# Altium schematic sheet BMS Carrier - Battery Relay Controls.SchDoc("BMS Carrier - Battery Relay Controls")
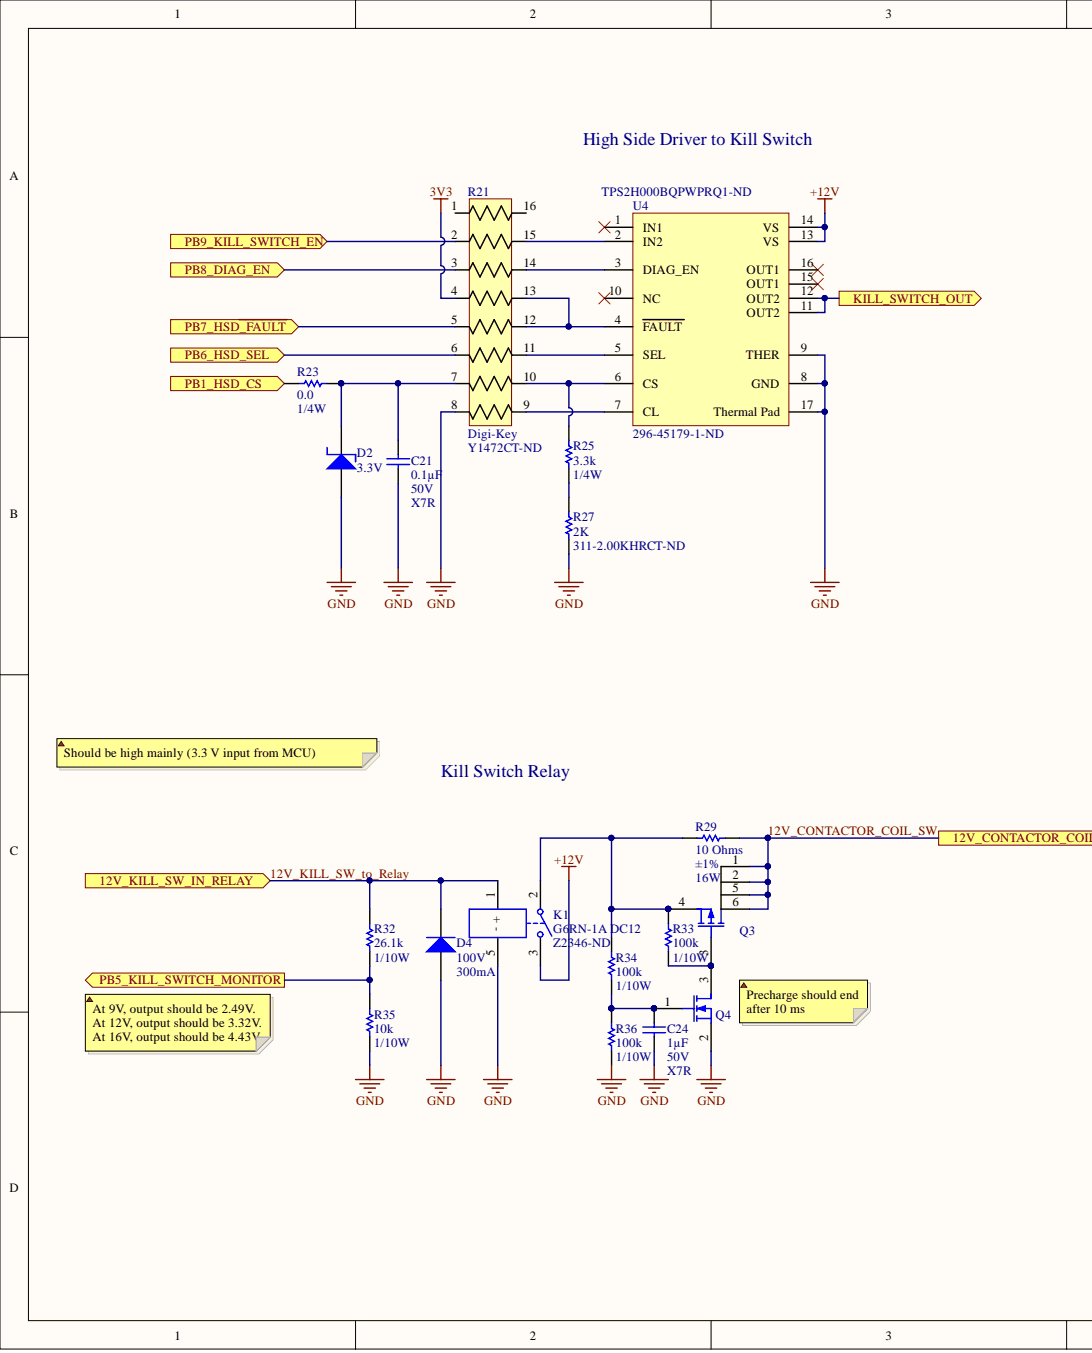
[diagram p0: BMS Carrier - Battery Relay Controls.SchDoc, left]
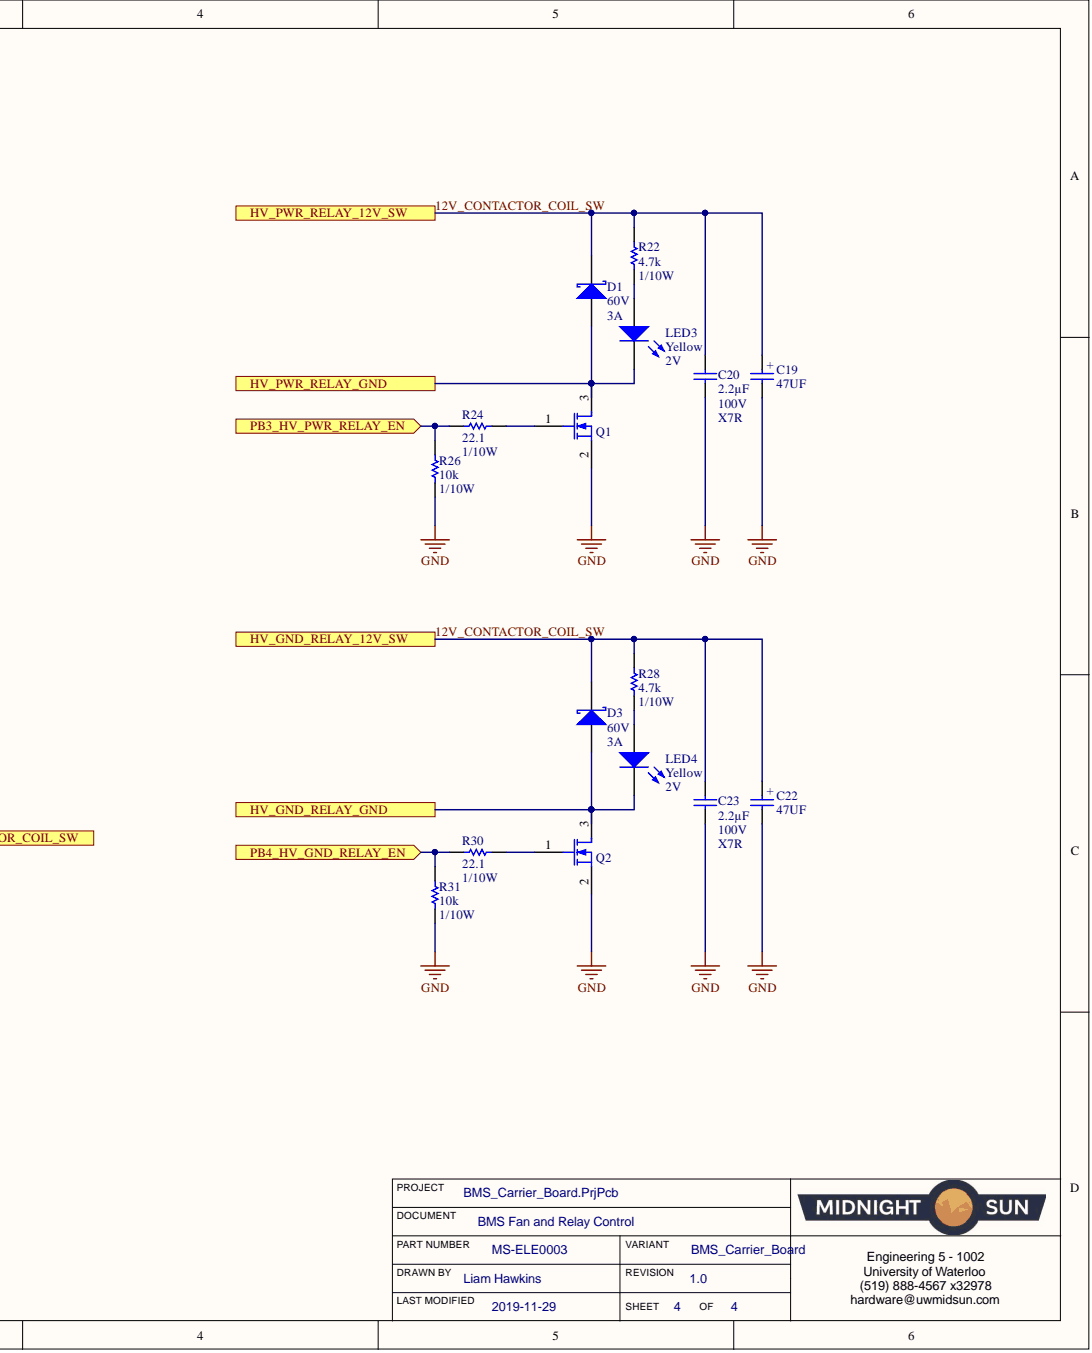
[diagram p1: BMS Carrier - Battery Relay Controls.SchDoc, right]

## Parts
C19  47UF  [CAP ALUM 6.3MM RADIAL SMD]
C20  Voltage: 100V  Temperature Coefficient: X7R  Capacitance: 2.2µF  [CAP, 1206]  (hidden comment: CAP CER 2.2UF 100V ±20% X7R 1206)
C21  Voltage: 50V  Temperature Coefficient: X7R  Capacitance: 0.1µF  [CAP, 0603]  (hidden comment: CAP CER 0.1UF 50V 10% X7R 0603)
C22  47UF  [CAP ALUM 6.3MM RADIAL SMD]
C23  Voltage: 100V  Temperature Coefficient: X7R  Capacitance: 2.2µF  [CAP, 1206]  (hidden comment: CAP CER 2.2UF 100V ±20% X7R 1206)
C24  Voltage: 50V  Temperature Coefficient: X7R  Capacitance: 1µF  [CAP, 0603]  (hidden comment: CAP CER 1UF 50V 10% X7R 0603)
D1  Voltage - DC Reverse (Vr) (Max): 60V  Current - Average Rectified (Io): 3A  [Diode, SMA]  (hidden comment: DIODE SCHOTTKY 60V 3A SMA)
D2  Zener Voltage: 3.3V  [sot23]  (hidden comment: DIODE ZENER 3.3V 250mW)
D3  Voltage - DC Reverse (Vr) (Max): 60V  Current - Average Rectified (Io): 3A  [Diode, SMA]  (hidden comment: DIODE SCHOTTKY 60V 3A SMA)
D4  Max Repetitive Reverse Voltage (Vrrm): 100V  Forward Current: 300mA  [DIODE GEN PURP 100V 300MA SOD123]  (hidden comment: DIODE GEN PURP 100V 300MA SOD123)
K1  G6RN-1A DC12  Supplier Part Number 2: Z2346-ND  [RELAY SPST 8A 12VDC]
LED3  Voltage - Forward (Vf) (Typ): 2V  Color: Yellow  [LED_0603]  (hidden comment: LED YELLOW CLEAR 2.1V 0603)
LED4  Voltage - Forward (Vf) (Typ): 2V  Color: Yellow  [LED_0603]  (hidden comment: LED YELLOW CLEAR 2.1V 0603)
Q1  MOSFET N-CH 30V 6.2A 0.9W SOT-23  [MOSFET N-CH 30V 6.2A 0.9W SOT-23]  (value not drawn)
Q2  MOSFET N-CH 30V 6.2A 0.9W SOT-23  [MOSFET N-CH 30V 6.2A 0.9W SOT-23]  (value not drawn)
Q3  MOSFET P-CH 30V 4A 1.6W SOT-23-6  [P-MOSFET]  (value not drawn)
Q4  MOSFET N-CH 30V 6.2A 0.9W SOT-23  [MOSFET N-CH 30V 6.2A 0.9W SOT-23]  (value not drawn)
R21  Supplier Part Number 1: Y1472CT-ND  Supplier 1: Digi-Key  [RES ARRAY, 8 POS 1506]  (hidden comment: RES ARRAY 4K7 OHM 5% 8RES)
R22  Resistance (Ohms): 4.7k  Power (Watts): 1/10W  [RES, 0603]  (hidden comment: RES 4.7K OHM 1% 1/10W 0603)
R23  Resistance: 0.0  [RES, 0603]  (hidden comment: RES 0.0 OHM 1/4W 0603)
R24  Resistance: 22.1  [RES, 0603]  (hidden comment: RES 22.1 OHM 1% 1/10W 0603)
R25  Resistance: 3.3k  Power: 1/4W  [RES, 0603]  (hidden comment: RES 3.3K OHM 1% 1/4W 0603)
R26  Resistance: 10k  Power: 1/10W  [RES, 0603]  (hidden comment: RES 10K OHM 1% 1/10W 0603)
R27  2K  Supplier Part Number 1: 311-2.00KHRCT-ND  [RES, 0603]
R28  Resistance (Ohms): 4.7k  Power (Watts): 1/10W  [RES, 0603]  (hidden comment: RES 4.7K OHM 1% 1/10W 0603)
R29  Resistance: 10 Ohms  [RES2512]  (hidden comment: RES 10 OHM 1% 16W 2512)
R30  Resistance: 22.1  [RES, 0603]  (hidden comment: RES 22.1 OHM 1% 1/10W 0603)
R31  Resistance: 10k  Power: 1/10W  [RES, 0603]  (hidden comment: RES 10K OHM 1% 1/10W 0603)
R32  Resistance: 26.1k  Power: 1/10W  [RES, 0603]  (hidden comment: RES 26.1K OHM 1% 1/10W 0603)
R33  Resistance: 100k  Power: 1/10W  [RES, 0603]  (hidden comment: RES 100K OHM 5% 1/8W 0603)
R34  Resistance: 100k  Power: 1/10W  [RES, 0603]  (hidden comment: RES 100K OHM 5% 1/8W 0603)
R35  Resistance: 10k  Power: 1/10W  [RES, 0603]  (hidden comment: RES 10K OHM 1% 1/10W 0603)
R36  Resistance: 100k  Power: 1/10W  [RES, 0603]  (hidden comment: RES 100K OHM 5% 1/8W 0603)
U4  296-45179-1-ND  Supplier Part Number 1: TPS2H000BQPWPRQ1-ND  [IC HSD Dual-Channel TSOP65P640X120_HS-17N]

## Ports
12V_CONTACTOR_COIL_SW, 12V_KILL_SW_IN_RELAY, HV_GND_RELAY_12V_SW, HV_GND_RELAY_GND, HV_PWR_RELAY_12V_SW, HV_PWR_RELAY_GND, KILL_SWITCH_OUT, PB1_HSD_CS, PB3_HV_PWR_RELAY_EN, PB4_HV_GND_RELAY_EN, PB5_KILL_SWITCH_MONITOR, PB6_HSD_SEL, PB7_HSD~{_FAULT}, PB8_DIAG_EN, PB9_KILL_SWITCH_EN

## Nets
3V3: R21.4
GND: C19.2 C20.2 C21.2 C22.2 C23.2 C24.2 D2.3 D4.1 K1.5(-) Q1.2 Q2.2 Q4.2 R21.8 R26.2 R27.2 R31.2 R35.2 R36.2 U4.8(GND) U4.9(THER) U4.17(Thermal_Pad)
+12V: C19.1 C20.1 C22.1 C23.1 D1.2 D3.2 D4.2 K1.1(+) K1.3 Q3.1(±1%) Q3.2(16W) Q3.5 Q3.6 R22.1 R28.1 R29.2 R32.1 U4.13(VS) U4.14(VS) ; port 12V_CONTACTOR_COIL_SW, port 12V_KILL_SW_IN_RELAY, port HV_GND_RELAY_12V_SW, port HV_PWR_RELAY_12V_SW  [also labelled 12V_CONTACTOR_COIL_SW, 12V_KILL_SW_to_Relay]
HV_GND_RELAY_GND: D3.1 LED4.2 Q2.3 ; port HV_GND_RELAY_GND
HV_PWR_RELAY_GND: D1.1 LED3.2 Q1.3 ; port HV_PWR_RELAY_GND
KILL_SWITCH_OUT: U4.11(OUT2) U4.12(OUT2) ; port KILL_SWITCH_OUT
PB1_HSD_CS: R23.1 ; port PB1_HSD_CS
PB3_HV_PWR_RELAY_EN: R24.1 R26.1 ; port PB3_HV_PWR_RELAY_EN
PB4_HV_GND_RELAY_EN: R30.1 R31.1 ; port PB4_HV_GND_RELAY_EN
PB5_KILL_SWITCH_MONITOR: R32.2 R35.1 ; port PB5_KILL_SWITCH_MONITOR
PB6_HSD_SEL: R21.6 ; port PB6_HSD_SEL
PB7_HSD~{_FAULT}: R21.5 ; port PB7_HSD~{_FAULT}
PB8_DIAG_EN: R21.3 ; port PB8_DIAG_EN
PB9_KILL_SWITCH_EN: R21.2 ; port PB9_KILL_SWITCH_EN
NetC21_1: C21.1 D2.1 R21.7 R23.2
NetC24_1: C24.1 Q4.1 R34.2 R36.1
NetK1_2: K1.2 Q3.4 R29.1 R33.2 R34.1
NetLED3_1: LED3.1 R22.2
NetLED4_1: LED4.1 R28.2
NetQ1_1: Q1.1 R24.2
NetQ2_1: Q2.1 R30.2
NetQ3_3: Q3.3 Q4.3 R33.1
NetR21_9: R21.9 U4.7(CL)
NetR21_10: R21.10 R25.1 U4.6(CS)
NetR21_11: R21.11 U4.5(SEL)
NetR21_12: R21.12 R21.13 U4.4(FAULT)
NetR21_14: R21.14 U4.3(DIAG_EN)
NetR21_15: R21.15 U4.2(IN2)
NetR25_2: R25.2 R27.1

Not connected: R21 1 16; U4 1(IN1) 10(NC) 15(OUT1) 16(OUT1)

## Library data of the parts
C19 C22 = CAP ALUM 47UF 20% 35V SMD: 47µF 35V Aluminum Electrolytic Capacitors Radial, Can - SMD 1000 Hrs @ 85°C; Manufacturer Part Number: EEE-1VA470WP; Manufacturer: Panasonic; Tolerance: 20%; Ripple Current (AC): 70mA; Life (Hours): 1000Hour; Voltage Rating (DC): 35V; Voltage Rating: 35V; Termination: SMD/SMT; Supplier 1: Digi-Key; Stock 1: 2820; Ripple Current: 70mA; REACH SVHC: Unknown; Radiation Hardening: No; Pricing 1: 1=0.38, 10=0.263, 100=0.1579, 500=0.11846 (USD); Packaging: Tape and Reel; Package Quantity: 1; Mount: Surface Mount,PCB; Min Operating Temperature: -40°C; Max Operating Temperature: 85°C; Length: 5.5mm; Lead Free: Lead Free; Height - Seated (Max): 0.213inch; Height: 5.4mm; Diameter: 6.3mm; Contact Plating: Tin; ComponentLink6URL: https://datasheet.ciiva.com/30206/11aba0000ce2-30206253.pdf; ComponentLink6Description: https://datasheet.ciiva.com/30206/11aba0000ce2-30206253.pdf; ComponentLink5URL: https://datasheet.ciiva.com/16507/aba0000ce2-16507965.pdf; ComponentLink5Description: https://datasheet.ciiva.com/16507/aba0000ce2-16507965.pdf; ComponentLink4URL: https://datasheet.ciiva.com/15165/getdatasheetpartid-86239-15165868.pdf; ComponentLink4Description: https://datasheet.ciiva.com/15165/getdatasheetpartid-86239-15165868.pdf; ComponentLink3URL: https://datasheet.ciiva.com/14598/70255710-14598937.pdf; ComponentLink3Description: https://datasheet.ciiva.com/14598/70255710-14598937.pdf; ComponentLink2URL: https://datasheet.ciiva.com/2983/aba0000ce2-2983927.pdf; ComponentLink2Description: https://datasheet.ciiva.com/2983/aba0000ce2-2983927.pdf; ComponentLink1URL: https://datasheet.ciiva.com/2983/aba0000ce2-2983926.pdf; ComponentLink1Description: https://datasheet.ciiva.com/2983/aba0000ce2-2983926.pdf; Component Kind: Standard; Case/Package: Radial; Capacitance: 47uF; Library Name: Schematic Diagrams.SchLib
C20 C23 = CAP CER 2.2UF 100V ±20% X7R 1206: 2.2µF ±20% 100V Ceramic Capacitor X7R 1206 (3216 Metric); Manufacturer Part Number 1: GRM31CR72A225MA73L; Manufacturer 1: Murata Electronics North America; Tolerance: ±20%; Thickness (Max): 0.071" (1.80mm); Supplier Part Number 1: 490-12773-1-ND; Supplier 1: Digi-Key; Size / Dimension: 0.126" L x 0.063" W (3.20mm x 1.60mm); Ratings: -; Part Status: Active; Packaging: Cut Tape (CT); Package / Case: 1206 (3216 Metric); Operating Temperature: -55°C ~ 125°C; Mounting Type: Surface Mount, MLCC; Lead Style: -; Lead Spacing: -; Height - Seated (Max): -; ComponentLink1URL: http://www.murata.com/~/media/webrenewal/support/library/catalog/products/capacitor/mlcc/c02e.ashx; ComponentLink1Description: http://www.murata.com/~/media/webrenewal/support/library/catalog/products/capacitor/mlcc/c02e.ashx; Component Kind: Standard; Library Name: Schematic Diagrams.SchLib
C21 = CAP CER 0.1UF 50V 10% X7R 0603: 0.10µF ±10% 50V Ceramic Capacitor X7R 0603 (1608 Metric); Manufacturer Part Number 1: 06035C104KAT2A; Manufacturer 1: AVX Corporation; Tolerance: ±10%; Thickness (Max): 0.035" (0.90mm); Supplier Part Number 1: 478-5052-1-ND; Supplier 1: Digi-Key; Size / Dimension: 0.063" L x 0.032" W (1.60mm x 0.81mm); Ratings: -; Part Status: Active; Packaging: Cut Tape (CT); Package / Case: 0603 (1608 Metric); Operating Temperature: -55°C ~ 125°C; Mounting Type: Surface Mount, MLCC; Lead Style: -; Lead Spacing: -; Height - Seated (Max): -; ComponentLink1URL: http://datasheets.avx.com/X7RDielectric.pdf; ComponentLink1Description: http://datasheets.avx.com/X7RDielectric.pdf; Component Kind: Standard; Library Name: Schematic Diagrams.SchLib
C24 = CAP CER 1UF 50V 10% X7R 0603: 1µF ±10% 50V Ceramic Capacitor X7R 0603 (1608 Metric); Manufacturer Part Number 1: UMK107AB7105KA-T; Manufacturer 1: Taiyo Yuden; Tolerance: ±10%; Thickness (Max): 0.037" (0.95mm); Supplier Part Number 1: 587-3247-1-ND; Supplier 1: Digi-Key; Size / Dimension: 0.063" L x 0.031" W (1.60mm x 0.80mm); Ratings: -; Part Status: Active; Packaging: Cut Tape (CT); Package / Case: 0603 (1608 Metric); Operating Temperature: -55°C ~ 125°C; Mounting Type: Surface Mount, MLCC; Lead Style: -; Lead Spacing: -; Height - Seated (Max): -; ComponentLink1URL: http://www.yuden.co.jp/productdata/catalog/en/mlcc_all_e.pdf; ComponentLink1Description: http://www.yuden.co.jp/productdata/catalog/en/mlcc_all_e.pdf; Component Kind: Standard; Library Name: Schematic Diagrams.SchLib
D1 D3 = DIODE SCHOTTKY 60V 3A SMA: Diode Schottky 60V 3A Surface Mount SMA; Manufacturer Part Number 1: B360A-13-F; Manufacturer 1: Diodes Incorporated; Voltage - Forward (Vf) (Max) @ If: 700mV @ 3A; Speed: Fast Recovery =< 500ns, > 200mA (Io); Current - Reverse Leakage @ Vr: 500µA @ 60V; Capacitance @ Vr, F: 200pF @ 4V, 1MHz; Supplier Part Number 1: B360A-FDICT-ND; Supplier Device Package: SMA; Supplier 1: Digi-Key; Part Status: Active; Packaging: Cut Tape (CT); Package / Case: DO-214AC, SMA; Operating Temperature - Junction: -55°C ~ 150°C; Mounting Type: Surface Mount; Diode Type: Schottky; ComponentLink1URL: https://www.diodes.com/assets/Datasheets/ds30891.pdf; ComponentLink1Description: https://www.diodes.com/assets/Datasheets/ds30891.pdf; Component Kind: Standard; Library Name: Schematic Diagrams.SchLib
D2 = DIODE ZENER 3.3V 250mW: Zener Diode 3.3V 250mW ±6.06% Surface Mount SSD3; Manufacturer Part Number: BZX84C3V3LFHT116; Manufacturer: Rohm; Impedance: 95R; Tolerance: 6.06%; Supplier Part Number 1: BZX84C3V3LFHT116CT-ND; Supplier 1: Digi-Key; Stock 1: 2960; RoHS: No; Pricing 1: 1=0.13000, 10=0.12200, 25=0.11600, 100=0.06630, 250=0.04912, 500=0.04078, 1000=0.02781 (USD); Packaging: Cut Tape; Mount: Surface Mount; Max Power Dissipation: 250mW; ComponentLink3URL: https://www.rohm.com/datasheet/BZX84C3V3LFH/bzx84c3v3lfht116-e?src-supplier=Digi-Key; ComponentLink3Description: https://www.rohm.com/datasheet/BZX84C3V3LFH/bzx84c3v3lfht116-e?src-supplier=Digi-Key; ComponentLink2URL: http://rohmfs.rohm.com/en/techdata_basic/diode/taping/Taping_SSD3M_T116-e.pdf?src-supplier=Digi-Key; ComponentLink2Description: http://rohmfs.rohm.com/en/techdata_basic/diode/taping/Taping_SSD3M_T116-e.pdf?src-supplier=Digi-Key; ComponentLink1URL: http://www.farnell.com/datasheets/2328411.pdf?src-supplier=Farnell; ComponentLink1Description: http://www.farnell.com/datasheets/2328411.pdf?src-supplier=Farnell; Component Kind: Standard; Case/Package: TO-236-3; Library Name: Schematic Diagrams.SchLib
D4 = DIODE GEN PURP 100V 300MA SOD123: Diode Standard 100V 300mA (DC) Surface Mount SOD-123; Manufacturer Part Number: 1N4148WQ-7-F; Manufacturer: Diodes Zetex; Reverse Recovery Time: 4ns; Peak Reverse Current: 50uA; Peak Non-Repetitive Surge Current: 2A; Max Power Dissipation: 400mW; Forward Voltage: 1.25V; Element Configuration: Single; Weight: 0.000353oz; Supplier Part Number 1: 1N4148WQ-7-FDICT-ND; Supplier 1: Digi-Key; Stock 1: 229; Recovery Time: 4ns; Pricing 1: 1=0.22, 10=0.196, 100=0.107, 500=0.0658, 1000=0.0449 (USD); Packaging: Tape and Reel; Package Quantity: 3000; Number of Pins: 2; Mount: Surface Mount; Min Operating Temperature: -65°C; Max Surge Current: 2A; Max Operating Temperature: 150°C; Lead Free: Lead Free; Contact Plating: Tin,Matte; ComponentLink3URL: http://www.mouser.com/ds/2/115/ds30086-318161.pdf; ComponentLink3Description: http://www.mouser.com/ds/2/115/ds30086-318161.pdf; ComponentLink2URL: http://www.diodes.com/datasheets/ds30086.pdf; ComponentLink2Description: http://www.diodes.com/datasheets/ds30086.pdf; ComponentLink1URL: http://components.arrow.com/part/dataview/0323_07206809; ComponentLink1Description: http://components.arrow.com/part/dataview/0323_07206809; Component Kind: Standard; Case/Package: SOD-123-2; Library Name: Schematic Diagrams.SchLib
K1 = RELAY SPST 12V 8A OMRON: Omron Relay SPST (1 Form A) Through Hole; Switching Voltage: 250VAC, 30VDC - Max; Operate Time: 15ms; Coil Voltage: 12V; Coil Type: Non Latching; Coil Power: 220 mW; Supplier 2: Digi-Key; Supplier 1: Digi-Key; Manufacturer Part Number: G6RN-1A DC12; Contact Form: SPST; ComponentLink1URL: https://omronfs.omron.com/en_US/ecb/products/pdf/en-g6rn.pdf; ComponentLink1Description: Datasheet; Component Kind: Standard; Library Name: Schematic Diagrams.SchLib
LED3 LED4 = LED YELLOW CLEAR 2.1V 0603: Yellow 590nm LED Indication - Discrete 2V 0603 (1608 Metric); Manufacturer Part Number: 150060YS75000; Manufacturer: Wurth Electronics Inc.; Wavelength - Peak: 595nm; Viewing Angle: 140°; Millicandela Rating: 120mcd; Lens Style/Size: Rectangle with Flat Top, 1.10mm x 0.70mm; Lens Color: Colorless; Current - Test: 20mA; Wavelength - Dominant: 590nm; Supplier Part Number 1: 732-4981-1-ND; Supplier Device Package: 0603; Supplier 1: Digi-Key; Stock 1: 10523; Size / Dimension: 1.60mm L x 0.80mm W; RoHS: RoHS Compliant; Pricing 1: 1=0.21, 100=0.1617, 500=0.13964 (CAD); Part Status: Active; Packaging: Cut Tape (CT); Package / Case: 0603 (1608 Metric); Mounting Type: Surface Mount; Lens Transparency: Clear; Height (Max): 0.80mm; Features: -; ComponentLink1URL: http://katalog.we-online.de/led/datasheet/150060YS75000.pdf; ComponentLink1Description: http://katalog.we-online.de/led/datasheet/150060YS75000.pdf; Component Kind: Standard; Category: Optoelectronics; Library Name: Schematic Diagrams.SchLib
Q1 Q2 Q4 = MOSFET N-CH 30V 6.2A 0.9W SOT-23: N-Channel 30V 6.2A (Ta) 900mW (Ta) Surface Mount SOT-23; Manufacturer Part Number: DMN3023L-7; Manufacturer: Diodes; Rds On Max: 25mR; Input Capacitance: 873pF; Supplier Part Number 1: DMN3023L-7DICT-ND; Supplier 1: Digi-Key; Stock 1: 38246; Pricing 1: 1=0.47, 10=0.368, 100=0.2529, 500=0.1734, 1000=0.1301 (USD); Packaging: Tape and Reel; Mount: Surface Mount; Min Operating Temperature: -55°C; Max Power Dissipation: 900mW; Max Operating Temperature: 150°C; Drain to Source Voltage (Vdss): 30V; Continuous Drain Current (ID): 6.2A; ComponentLink2URL: http://www.mouser.com/ds/2/115/DMN3023L-959533.pdf; ComponentLink2Description: http://www.mouser.com/ds/2/115/DMN3023L-959533.pdf; ComponentLink1URL: https://www.diodes.com/assets/Datasheets/DMN3023L.pdf; ComponentLink1Description: https://www.diodes.com/assets/Datasheets/DMN3023L.pdf; Component Kind: Standard; Case/Package: TO-236-3; Library Name: Schematic Diagrams.SchLib
Q3 = MOSFET P-CH 30V 4A 1.6W SOT-23-6: P-Channel 30V 4A (Ta) 1.6W (Ta) Surface Mount SOT-23-6; Manufacturer Part Number 1: STT4P3LLH6; Manufacturer 1: STMicroelectronics; Vgs(th) (Max) @ Id: 2.5V @ 250µA; Vgs (Max): ±20V; Rds On (Max) @ Id, Vgs: 56 mOhm @ 2A, 10V; Input Capacitance (Ciss) (Max) @ Vds: 639pF @ 25V; Gate Charge (Qg) (Max) @ Vgs: 6nC @ 4.5V; Drive Voltage (Max Rds On,  Min Rds On): 4.5V, 10V; Current - Continuous Drain (Id) @ 25°C: 4A (Ta); Technology: MOSFET (Metal Oxide); Supplier Part Number 1: 497-15521-1-ND; Supplier Device Package: SOT-23-6; Supplier 1: Digi-Key; Simulation: PMOS; Power Dissipation (Max): 1.6W (Ta); Part Status: Active; Packaging: Cut Tape (CT); Package / Case: SOT-23-6; Operating Temperature: 150°C (TJ); Mounting Type: Surface Mount; FET Type: P-Channel; FET Feature: -; Drain to Source Voltage (Vdss): 30V; ComponentLink1URL: http://www.st.com/content/ccc/resource/technical/document/datasheet/13/e4/a1/65/8b/79/44/73/DM00084060.pdf/files/DM00084060.pdf/jcr:content/translations/en.DM00084060.pdf; ComponentLink1Description: http://www.st.com/content/ccc/resource/technical/document/datasheet/13/e4/a1/65/8b/79/44/73/DM00084060.pdf/files/DM00084060.pdf/jcr:content/translations/en.DM00084060.pdf; Component Kind: Standard; Library Name: Schematic Diagrams.SchLib
R21 = RES ARRAY 4.7K OHM 8 RES 1506: RES ARRAY SMD 4K7 5% 62.5mW 1506; Manufacturer Part Number: EXB-2HV472JV; Manufacturer: Panasonic Electronic Components; Vendor: Panasonic; Temperature Coefficient: ±200ppm/°C; Circuit Type: Isolated; Tolerance: ±5%; Supplier Device Package: 1506; Size / Dimension: 0.150" L x 0.063" W (3.80mm x 1.60mm); RoHS: RoHS Compliant; Resistance (Ohms): 4K7; Power Per Element: 62.5mW; Part Status: Active; Packaging: Cut Tape (CT); Package / Case: 1506, Convex, Long Side Terminals; Operating Temperature: -55°C ~ 125°C; Mounting Type: Surface Mount; Height - Seated (Max): 0.022" (0.55mm); ComponentLink2URL: https://industrial.panasonic.com/cdbs/www-data/pdf/AOC0000/AOC0000C14.pdf; ComponentLink2Description: Series Catalog; Component Kind: Standard; Category: Resistors; Applications: Automotive AEC-Q200; Library Name: Schematic Diagrams.SchLib
R22 R28 = RES 4.7K OHM 1% 1/10W 0603: 4.7k Ohm ±1% 0.1W, 1/10W Chip Resistor 0603 (1608 Metric) Moisture Resistant Thick Film; Manufacturer Part Number 1: RC0603FR-074K7L; Manufacturer 1: Yageo; Temperature Coefficient: ±100ppm/°C; Description 1: RES SMD 4.7K OHM 1% 1/10W 0603; Tolerance: ±1%; Supplier Part Number 1: 311-4.70KHRCT-ND; Supplier Device Package: 0603; Supplier 1: Digi-Key; Stock 1: 3487566; Size / Dimension: 0.063" L x 0.031" W (1.60mm x 0.80mm); RoHS: RoHS Compliant; Pricing 1: 1=0.16, 10=0.022, 100=0.0089, 1000=0.00402, 2500=0.00349 (CAD); Part Status: Active; Packaging: Cut Tape (CT); Package / Case: 0603 (1608 Metric); Operating Temperature: -55°C ~ 155°C; Height - Seated (Max): 0.022" (0.55mm); Features: Moisture Resistant; Failure Rate: -; Composition: Thick Film; ComponentLink1URL: http://www.yageo.com/documents/recent/PYu-RC_Group_51_RoHS_L_7.pdf; ComponentLink1Description: http://www.yageo.com/documents/recent/PYu-RC_Group_51_RoHS_L_7.pdf; Component Kind: Standard; Category: Resistors; Library Name: Schematic Diagrams.SchLib
R23 = RES 0.0 OHM 1/4W 0603: RES SMD 0.0 OHM JUMPER 1/4W 0603; Manufacturer Part Number 1: CRCW06030000Z0EAHP; Manufacturer 1: Vishay Dale; Supplier Part Number 1: 541-0.0SBCT-ND; Supplier Device Package: 0603; Supplier 1: Digi-Key; Size / Dimension: 0.063" L x 0.033" W (1.60mm x 0.85mm); Power (Watts): 1/4W; Part Status: Active; Packaging: Cut Tape (CT); Package / Case: 0603 (1608 Metric); Operating Temperature: -55°C ~ 155°C; Height - Seated (Max): 0.022" (0.55mm); Failure Rate: -; Composition: Thick Film; ComponentLink1URL: http://www.vishay.com/docs/20043/crcwhpe3.pdf; ComponentLink1Description: http://www.vishay.com/docs/20043/crcwhpe3.pdf; Component Kind: Standard; Library Name: Schematic Diagrams.SchLib
R24 R30 = RES 22.1 OHM 1% 1/10W 0603: 22.1 Ohm ±1% 0.1W, 1/10W Chip Resistor 0603 (1608 Metric) Moisture Resistant Thick Film; Manufacturer Part Number 1: RC0603FR-0722R1L; Manufacturer 1: Yageo; Temperature Coefficient: ±100ppm/°C; Tolerance: ±1%; Supplier Part Number 1: 311-22.1HRCT-ND; Supplier Device Package: 0603; Supplier 1: Digi-Key; Size / Dimension: 0.063" L x 0.031" W (1.60mm x 0.80mm); Power: 1/10W; Part Status: Active; Packaging: Cut Tape (CT); Package / Case: 0603 (1608 Metric); Operating Temperature: -55°C ~ 155°C; Height - Seated (Max): 0.022" (0.55mm); Failure Rate: -; Composition: Thick Film; ComponentLink1URL: http://www.yageo.com/documents/recent/PYu-RC_Group_51_RoHS_L_7.pdf; ComponentLink1Description: http://www.yageo.com/documents/recent/PYu-RC_Group_51_RoHS_L_7.pdf; Component Kind: Standard; Library Name: Schematic Diagrams.SchLib
R25 = RES 3.3K OHM 1% 1/4W 0603: 3.3k Ohm ±1% 0.25W, 1/4W Chip Resistor 0603 (1608 Metric) Automotive AEC-Q200, Pulse Withstanding Thick Film; Manufacturer Part Number 1: ERJ-PA3F3301V; Manufacturer 1: Panasonic Electronic Components; Temperature Coefficient: ±100ppm/°C; Tolerance: ±1%; Supplier Part Number 1: P3.3KBYCT-ND; Supplier Device Package: 0603; Supplier 1: Digi-Key; Size / Dimension: 0.063" L x 0.031" W (1.60mm x 0.80mm); Part Status: Active; Packaging: Cut Tape (CT); Package / Case: 0603 (1608 Metric); Operating Temperature: -55°C ~ 155°C; Height - Seated (Max): 0.022" (0.55mm); Failure Rate: -; Composition: Thick Film; ComponentLink1URL: https://industrial.panasonic.com/cdbs/www-data/pdf/RDO0000/AOA0000C291.pdf; ComponentLink1Description: https://industrial.panasonic.com/cdbs/www-data/pdf/RDO0000/AOA0000C291.pdf; Component Kind: Standard; Library Name: Schematic Diagrams.SchLib
R26 R31 R35 = RES 10K OHM 1% 1/10W 0603: RES SMD 10K OHM 1% 1/10W 0603; Manufacturer Part Number 1: RC0603FR-0710KL; Manufacturer 1: Yageo; Temperature Coefficient: ±100ppm/°C; Tolerance: ±1%; Supplier Part Number 1: 311-10.0KHRCT-ND; Supplier Device Package: 0603; Supplier 1: Digi-Key; Size / Dimension: 0.063" L x 0.031" W (1.60mm x 0.80mm); Part Status: Active; Packaging: Cut Tape (CT); Package / Case: 0603 (1608 Metric); Operating Temperature: -55°C ~ 155°C; Height - Seated (Max): 0.022" (0.55mm); Failure Rate: -; Composition: Thick Film; ComponentLink1URL: http://www.yageo.com/documents/recent/PYu-RC_Group_51_RoHS_L_7.pdf; ComponentLink1Description: http://www.yageo.com/documents/recent/PYu-RC_Group_51_RoHS_L_7.pdf; Component Kind: Standard; Library Name: Schematic Diagrams.SchLib
R27 = RES 2K OHM 1% 1/10W 0603: 2k Ohm ±1% 0.1W, 1/10W Chip Resistor 0603 (1608 Metric) Moisture Resistant Thick Film; Manufacturer Part Number: RC0603FR-072KL; Manufacturer: Yageo; Temperature Coefficient: ±100ppm/°C; Tolerance: ±1%; Supplier Device Package: 0603; Supplier 1: Digi-Key; Stock 1: 1750701; Size / Dimension: 0.063" L x 0.031" W (1.60mm x 0.80mm); RoHS: RoHS Compliant; Resistance (Ohms): 2k; Pricing 1: 1=0.15, 10=0.023, 100=0.0093, 1000=0.00415, 2500=0.0036 (CAD); Power (Watts): 0.1W, 1/10W; Part Status: Active; Packaging: Cut Tape (CT); Package / Case: 0603 (1608 Metric); Operating Temperature: -55°C ~ 155°C; Height - Seated (Max): 0.022" (0.55mm); Features: Moisture Resistant; Failure Rate: -; Composition: Thick Film; ComponentLink1URL: http://www.yageo.com/documents/recent/PYu-RC_Group_51_RoHS_L_7.pdf; ComponentLink1Description: http://www.yageo.com/documents/recent/PYu-RC_Group_51_RoHS_L_7.pdf; Component Kind: Standard; Category: Resistors; Library Name: Schematic Diagrams.SchLib
R29 = RES 10 OHM 1% 16W 2512: 10 Ohms ±1% 16W Chip Resistor 2512 (6432 Metric) Moisture Resistant Thin Film; Temperature Coefficient: ±50ppm/°C; Tolerance: ±1%; Supplier Part Number 1: CPA25Q10.0CT-ND; Supplier Device Package: 2512; Supplier 1: Digi-Key; Size / Dimension: 0.248" L x 0.126" W (6.30mm x 3.20mm); Power: 16W; Part Status: Active; Packaging: Cut Tape (CT); Package / Case: 2512 (6432 Metric); Operating Temperature: -55°C ~ 155°C; Height - Seated (Max): 0.031" (0.80mm); Failure Rate: -; Composition: Thin Film; ComponentLink2URL: https://media.digikey.com/pdf/Data%20Sheets/Susumu%20PDFs/CPA2512%20Series%20Appl%20Note.pdf; ComponentLink2Description: Application Note; ComponentLink1URL: https://www.susumu.co.jp/common/pdf/CPA.pdf; ComponentLink1Description: Datasheet; Component Kind: Standard; Library Name: Schematic Diagrams.SchLib
R32 = RES 26.1K OHM 1% 1/10W 0603: RES SMD 26.1K OHM 1% 1/10W 0603; Manufacturer Part Number 1: RC0603FR-0726K1L; Manufacturer 1: Yageo; Temperature Coefficient: ±100ppm/°C; Tolerance: ±1%; Supplier Part Number 1: 311-26.1KHRCT-ND; Supplier Device Package: 0603; Supplier 1: Digi-Key; Size / Dimension: 0.063" L x 0.031" W (1.60mm x 0.80mm); Part Status: Active; Packaging: Cut Tape (CT); Package / Case: 0603 (1608 Metric); Operating Temperature: -55°C ~ 155°C; Height - Seated (Max): 0.022" (0.55mm); Failure Rate: -; Composition: Thick Film; ComponentLink1URL: http://www.yageo.com/documents/recent/PYu-RC_Group_51_RoHS_L_7.pdf; ComponentLink1Description: http://www.yageo.com/documents/recent/PYu-RC_Group_51_RoHS_L_7.pdf; Component Kind: Standard; Library Name: Schematic Diagrams.SchLib
R33 R34 R36 = RES 100K OHM 5% 1/8W 0603: RES SMD 100K OHM 5% 1/10W 0603; Manufacturer Part Number 1: RC0603JR-07100KL; Manufacturer 1: Yageo; Temperature Coefficient: ±100ppm/°C; Tolerance: ±5%; Supplier Part Number 1: 311-100KGRCT-ND; Supplier Device Package: 0603; Supplier 1: Digi-Key; Size / Dimension: 0.063" L x 0.031" W (1.60mm x 0.80mm); Part Status: Active; Packaging: Cut Tape (CT); Package / Case: 0603 (1608 Metric); Operating Temperature: -55°C ~ 155°C; Height - Seated (Max): 0.022" (0.55mm); Failure Rate: -; Composition: Thick Film; ComponentLink1URL: http://www.yageo.com/documents/recent/PYu-RC_Group_51_RoHS_L_7.pdf; ComponentLink1Description: http://www.yageo.com/documents/recent/PYu-RC_Group_51_RoHS_L_7.pdf; Component Kind: Standard; Library Name: Schematic Diagrams.SchLib
U4 = IC HSD Dual-Channel 40V 1KOhm: Supplier Part Number: 296-45179-1-ND; Supplier 1: Digi-Key; Manufacturer Part Number: TPS2H000BQPWPRQ1-ND; Description: IC HSD Dual-Channel 40V 1KOhm; ComponentLink1URL: http://www.ti.com/lit/ds/symlink/tps2h000-q1.pdf; ComponentLink1Description: Datasheet; Component Kind: Standard; Library Name: Schematic Diagrams.SchLib
